# Altium schematic sheet BaseFunctions.SchDoc
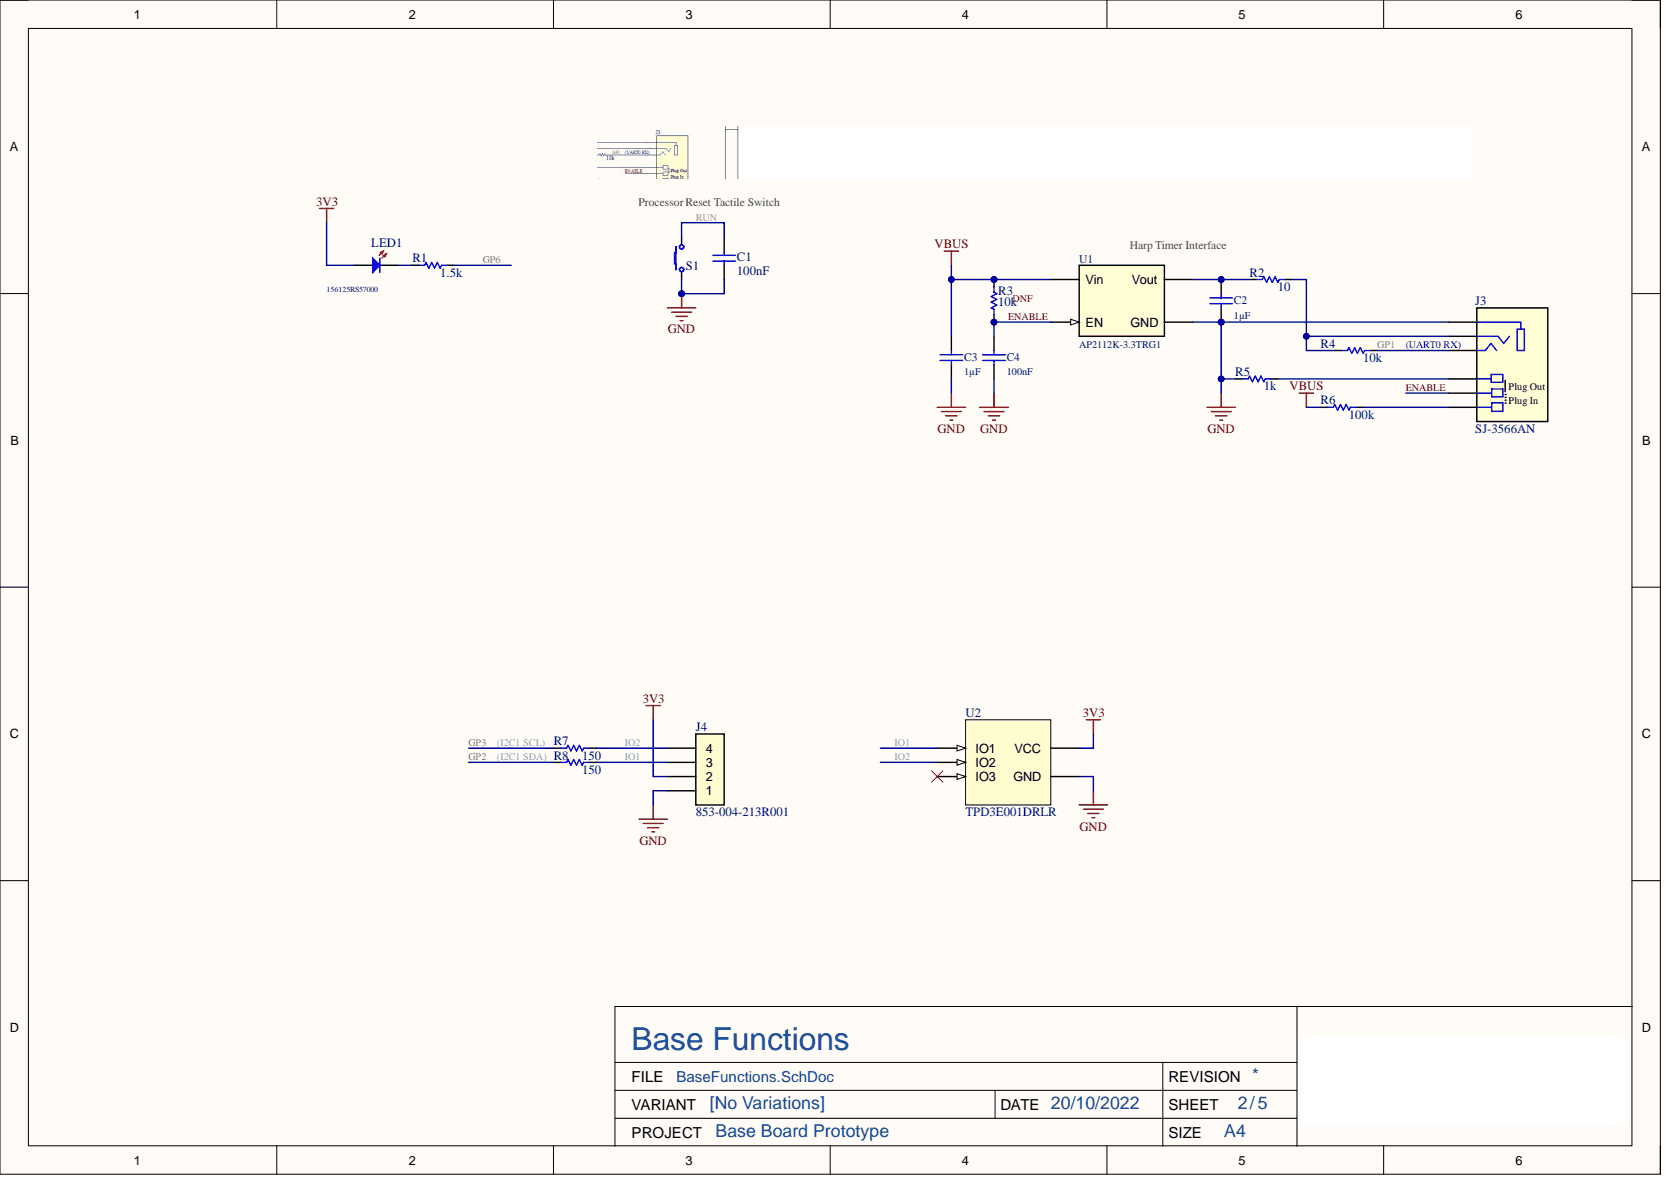
[diagram p0: BaseFunctions.SchDoc]

## Parts
C1  value 100nF  Capacitance: 100nF  [FP-GRM188-0_1-e0_2_0_5-IPC_B]  (hidden comment: C0603-100nF-100V-10%-X7R)
C2  value 1µF  [FP-GRM188-0_1-e0_2_0_5-IPC_B]  (hidden comment: C0603-1uF-25V-10%-X7R)
C3  value 1µF  [FP-GRM188-0_1-e0_2_0_5-IPC_B]  (hidden comment: C0603-1uF-25V-10%-X7R)
C4  value 100nF  Capacitance: 100nF  [FP-GRM188-0_1-e0_2_0_5-IPC_B]  (hidden comment: C0603-100nF-100V-10%-X7R)
J3  SJ-3566AN  [CUI_SJ-3566AN - RM]
J4  853-004-213R001  [853-004-213R00Y]
LED1  156125RS57000  [WL-SMRD_1205_normal use]
R1  value 1.5k  Resistance: 1.5k  [FP-CPF0603-IPC_B]  (hidden comment: R0603-1k5-1/16W-0.1%)
R2  value 10  [FP-CPF0603-IPC_B]  (hidden comment: R0603-10R-1/16W-0.1%)
R3  value 10k  Resistance: 10k  [FP-CPF0603-IPC_B]  (hidden comment: R0603-10k-1/16W-0.1%)
R4  value 10k  Resistance: 10k  [FP-CPF0603-IPC_B]  (hidden comment: R0603-10k-1/16W-0.1%)
R5  value 1k  Resistance: 1k  [FP-CPF0603-IPC_B]  (hidden comment: R0603-1k-1/16W-0.1%)
R6  value 100k  Resistance: 100k  [FP-CPF0603-IPC_B]  (hidden comment: R0603-100k-1/16W-0.1%)
R7  value 150  [FP-CPF0603-IPC_B]  (hidden comment: R0603-150R-1/16W-0.1%)
R8  value 150  [FP-CPF0603-IPC_B]  (hidden comment: R0603-150R-1/16W-0.1%)
S1  PTS645VM83-2LFS  [PTS645VM83-2LFS]  (value not drawn)
U1  AP2112K-3.3TRG1  [FP-SOT25-IPC_B]
U2  TPD3E001DRLR  [DRL5_TEX]

## Nets
3V3: J4.2 LED1.1 U2.5(VCC)
GND: C1.1 C2.1 C3.1 C4.1 J3.1 J4.1 R5.1 S1.1 U1.2(GND) U2.3(GND)
VBUS: C3.2 R3.2 R6.1 U1.1(Vin)
ENABLE: C4.2 J3.5 R3.1 U1.3(EN)
GP1: J3.2 R4.2
GP2: R8.1
GP3: R7.1
GP6: R1.2
IO1: J4.3 R8.2 U2.1(IO1)
IO2: J4.4 R7.2 U2.2(IO2)
RUN: C1.2 S1.2
NetC2_2: C2.2 R2.1 U1.5(Vout)
NetJ3_3: J3.3 R2.2 R4.1
NetJ3_4: J3.4 R5.2
NetJ3_6: J3.6 R6.2
NetLED1_2: LED1.2 R1.1

Not connected: U2 4(IO3)

## Library data of the parts
C1 C4 = CMP-611-0027-15: 0.1µF ±10% 100V Ceramic Capacitor X7R 0603 (1608 Metric); Pick&PlaceFootprint: 0603_CAP; Capacitor Type: Ceramic; Case Code (Imperial): 0603; Case Code (Metric): 1608; Case/Package: 0603; Component Kind: Standard; Construction: Flat; Depth: 0.8mm; Dielectric: X7R; Height: 0.8mm; Lead Free: Lead Free; Length: 1.6mm; Material: Ceramic; Max Operating Temperature: 125°C; Min Operating Temperature: -55°C; Mount: Surface Mount; Package Quantity: 1; Packaging: Tape and Reel; Radiation Hardening: No; REACH SVHC: No SVHC; RoHS: Yes; RoHS Compliant: Yes; Series: GRM; Size: 0.8mm; Termination: SMD/SMT; Thickness: 0.035inch; Tolerance: 10%; Voltage: 100V; Voltage Rating: 100V; Voltage Rating (DC): 100V; Weight: 0.000222oz; Width: 0.8mm; Library Name: Sainsbury Wellcome Centre
C2 C3 = CMP-611-0033-2: 1µF ±10% 25V Ceramic Capacitor X7R 0603 (1608 Metric); Capacitance: 1uF; Pick&PlaceFootprint: 0603_CAP; Capacitor Type: Ceramic; Case Code (Imperial): 0603; Case Code (Metric): 1608; Case/Package: 0603; Component Kind: Standard; Construction: Flat; Depth: 0.8mm; Dielectric: X7R; Height: 0.9mm; Lead Free: Lead Free; Length: 1.6mm; Material: Ceramic; Max Operating Temperature: 125°C; Min Operating Temperature: -55°C; Mount: Surface Mount; Package Quantity: 1; Packaging: Tape and Reel; Radiation Hardening: No; REACH SVHC: Yes; RoHS: Yes; RoHS Compliant: Yes; Series: GRM; Size: 0.8mm; Termination: Wraparound; Thickness: 0.035inch; Tolerance: 10%; Voltage: 25V; Voltage Rating: 25V; Voltage Rating (DC): 25V; Weight: 0.000222oz; Width: 0.8mm; Library Name: Sainsbury Wellcome Centre
J3 = CMP-300-0000-5: 3.5 mm, Stereo, Right Angle, Through Hole, SPDT Switch, Audio Jack Connector; Connector Type: AUDIO/RCA CONNECTOR; DIN Conformance: No; Number of Poles: 3; Number of Ports: 1; Option: General Purpose; Pick&PlaceFootprint: SJ-356xxx; Body/Shell Style: JACK; Component Kind: Standard; Contact Finish - Mating: NOT SPECIFIED; IEC Conformance: No; MIL Conformance: No; Mount: Through Hole; PartNumber: SJ-3566AN; REACH SVHC: Yes; RoHS Compliant: Yes; Termination: Solder; Library Name: Sainsbury Wellcome Centre
J4 = CMP-370-0028-2: CONN PLUG FMALE 4POS GOLD SOLDER; Connector Type: Receptacle; Current Rating: 1A; Number of Positions: 4; Ratings: IP67,Waterproof,Dust Tight; Voltage Rating: 60V; Component Kind: Standard; Contact Plating: Gold; Fastening Type: Threaded; Max Operating Temperature: 85°C; Min Operating Temperature: -40°C; Mount: Through Hole,Panel; Number of Pins: 4; Packaging: Tray; REACH SVHC: No SVHC; RoHS Compliant: Yes; Termination: Solder; Library Name: Sainsbury Wellcome Centre
LED1 = CMP-520-0011-1: Single Color LED; Component Kind: Standard; Mount: Surface Mount; Optoelectronic Device Type: SINGLE COLOR LED; PartNumber: 156125RS57000; REACH SVHC: Yes; RoHS Compliant: Yes; Library Name: Sainsbury Wellcome Centre
R1 = CMP-633-0029-14: Surface Mount Resistor 1k5 Ohm 1/16 W 0.1% 0603 (1608 Metric); Max Power Dissipation: 62.5 mW; Number of Resistors: 1; Pick&PlaceFootprint: R0603; Power Rating: 62.5mW; Temperature Coefficient: 25ppm/°C; Voltage Rating: 50V; Case Code (Imperial): 0603; Case Code (Metric): 1608; Case/Package: 0603; Component Kind: Standard; Composition: Thin Film; ELV: Compliant; Height: 0.45mm; Length: 1.6mm; Max Operating Temperature: 155°C; Min Operating Temperature: -55°C; Mount: Surface Mount; Number of Pins: 2; Number of Terminations: 2; Packaging: Tape and Reel; REACH SVHC: No SVHC; Resistance Tolerance: 0.1%; Resistor Type: Thin Film; RoHS: Yes; RoHS Compliant: Yes; Series: CPF; Size Code: 0603; Technology: Thin Film; Termination: Solder; Tolerance: 0.1%; Voltage Rating (DC): 50V; Weight: 7.1E-05oz; Width: 0.8mm; Library Name: Sainsbury Wellcome Centre
R2 = CMP-633-0069-10: Surface Mount Resistor 10 Ohm 1/16 W 0.1% 0603 (1608 Metric); Max Power Dissipation: 62.5 mW; Number of Resistors: 1; Pick&PlaceFootprint: R0603; Power Rating: 62.5mW; Temperature Coefficient: 25ppm/°C; Voltage Rating: 50V; Case Code (Imperial): 0603; Case Code (Metric): 1608; Case/Package: 0603; Component Kind: Standard; Composition: Thin Film; ELV: Compliant; Height: 0.45mm; Length: 1.6mm; Max Operating Temperature: 155°C; Min Operating Temperature: -55°C; Mount: Surface Mount; Number of Pins: 2; Number of Terminations: 2; Packaging: Tape and Reel; REACH SVHC: No SVHC; Resistance: 10; Resistance Tolerance: 0.1%; Resistor Type: Thin Film; RoHS: Yes; RoHS Compliant: Yes; Series: CPF; Size Code: 0603; Technology: Thin Film; Termination: Solder; Tolerance: 0.1%; Voltage Rating (DC): 50V; Weight: 7.1E-05oz; Width: 0.8mm; Library Name: Sainsbury Wellcome Centre
R3 R4 = CMP-633-0002-15: Surface Mount Resistor 10k Ohm 1/16 W 0.1% 0603 (1608 Metric); Max Power Dissipation: 62.5 mW; Number of Resistors: 1; Pick&PlaceFootprint: R0603; Power Rating: 62.5mW; Temperature Coefficient: 25ppm/°C; Voltage Rating: 50V; Case Code (Imperial): 0603; Case Code (Metric): 1608; Case/Package: 0603; Component Kind: Standard; Composition: Thin Film; ELV: Compliant; Height: 0.45mm; Length: 1.6mm; Max Operating Temperature: 155°C; Min Operating Temperature: -55°C; Mount: Surface Mount; Number of Pins: 2; Number of Terminations: 2; Packaging: Tape and Reel; REACH SVHC: No SVHC; Resistance Tolerance: 0.1%; Resistor Type: Thin Film; RoHS: Yes; RoHS Compliant: Yes; Series: CPF; Size Code: 0603; Technology: Thin Film; Termination: Solder; Tolerance: 0.1%; Voltage Rating (DC): 50V; Weight: 7.1E-05oz; Width: 0.8mm; Library Name: Sainsbury Wellcome Centre
R5 = CMP-633-0013-15: Surface Mount Resistor 1k Ohm 1/16 W 0.1% 0603 (1608 Metric); Max Power Dissipation: 62.5 mW; Number of Resistors: 1; Pick&PlaceFootprint: R0603; Power Rating: 62.5mW; Temperature Coefficient: 25ppm/°C; Voltage Rating: 50V; Case Code (Imperial): 0603; Case Code (Metric): 1608; Case/Package: 0603; Component Kind: Standard; Composition: Thin Film; ELV: Compliant; Height: 0.45mm; Length: 1.6mm; Max Operating Temperature: 155°C; Min Operating Temperature: -55°C; Mount: Surface Mount; Number of Pins: 2; Number of Terminations: 2; Packaging: Tape and Reel; REACH SVHC: No SVHC; Resistance Tolerance: 0.1%; Resistor Type: Thin Film; RoHS: Yes; RoHS Compliant: Yes; Series: CPF; Size Code: 0603; Technology: Thin Film; Termination: Solder; Tolerance: 0.1%; Voltage Rating (DC): 50V; Weight: 7.1E-05oz; Width: 0.8mm; Library Name: Sainsbury Wellcome Centre
R6 = CMP-633-0000-17: Surface Mount Resistor 100k Ohm 1/16 W 0.1% 0603 (1608 Metric); Max Power Dissipation: 62.5 mW; Number of Resistors: 1; Pick&PlaceFootprint: R0603; Power Rating: 62.5mW; Temperature Coefficient: 25ppm/°C; Voltage Rating: 50V; Case Code (Imperial): 0603; Case Code (Metric): 1608; Case/Package: 0603; Component Kind: Standard; Composition: Thin Film; ELV: Compliant; Height: 0.45mm; Length: 1.6mm; Max Operating Temperature: 155°C; Min Operating Temperature: -55°C; Mount: Surface Mount; Number of Pins: 2; Number of Terminations: 2; Packaging: Tape and Reel; REACH SVHC: No SVHC; Resistance Tolerance: 0.1%; Resistor Type: Thin Film; RoHS: Yes; RoHS Compliant: Yes; Series: CPF; Size Code: 0603; Technology: Thin Film; Termination: Solder; Tolerance: 0.1%; Voltage Rating (DC): 50V; Weight: 7.1E-05oz; Width: 0.8mm; Library Name: Sainsbury Wellcome Centre
R7 R8 = CMP-633-0078-2: Surface Mount Resistor 150 Ohm 1/16 W 0.1% 0603 (1608 Metric); Max Power Dissipation: 62.5 mW; Number of Resistors: 1; Pick&PlaceFootprint: R0603; Power Rating: 62.5mW; Temperature Coefficient: 25ppm/°C; Voltage Rating: 50V; Case Code (Imperial): 0603; Case Code (Metric): 1608; Case/Package: 0603; Component Kind: Standard; Composition: Thin Film; Construction: Chip; ELV: Compliant; Height: 0.45mm; Length: 1.6mm; Max Operating Temperature: 155°C; Min Operating Temperature: -55°C; Mount: Surface Mount; Number of Pins: 2; Number of Terminations: 2; Packaging: Tape and Reel; REACH SVHC: Yes; Resistance: 150; Resistance Tolerance: 0.1%; Resistor Type: FIXED RESISTOR; RoHS: Yes; RoHS Compliant: Yes; Series: CPF; Size Code: 0603; Technology: THIN FILM; Termination: Wraparound; Tolerance: 0.1%; Voltage Rating (DC): 50V; Weight: 7.1E-05oz; Width: 0.8mm; Library Name: Sainsbury Wellcome Centre
S1 = CMP-B00-0002-2: Switch Tactile N.O. SPST Round Button PC Pins 0.05A 12VDC 100000Cycles 1.57N Bracket Mount/Through Hole Bulk; Actuator Material: Nylon,Polyamide,PA66; Actuator Type: Standard; Current Rating: 50mA; Illumination Type: Non-Illuminated; Switch Function: Off-Mom; Voltage Rating (DC): 12V; Color: Black; Component Kind: Standard; Contact Plating: Silver; Depth: 12.3mm; Length: 7.5mm; Max Current Rating: 50mA; Max Operating Temperature: 60°C; Mechanical Life: 100000Cycles; Min Operating Temperature: -20°C; Mount: Through Hole; Operating Force: 1.57N; Orientation: Right Angle; Package Quantity: 1000; Packaging: Bulk; RoHS Compliant: Yes; Series: PTS645; Termination: PC Pins; Throw Configuration: SPST; Library Name: Sainsbury Wellcome Centre
U1 = CMP-970-0025-2: Fixed Positive LDO Regulator, 3.3V, 0.4V Dropout, CMOS, PDSO5; Dropout Voltage1-Max: 400mV; Dropout Voltage1-Nom: 250mV; Input Voltage Absolute-Max: 6.5V; Line Regulation-Max: 0.00561%; Load Regulation-Max: 0.0198%; Max Input Voltage: 6V; Min Input Voltage: 2.5V; Number of Functions: 1; Output Current1-Max: 600mA; Output Voltage1-Max: 3.3495V; Output Voltage1-Min: 3.2505V; Package Body Material: Plastic; Package Equivalence Code: TSOP5/6,.11,37; Pick&PlaceFootprint: SOT25; Qualification Status: Not Qualified; Regulator Type: FIXED POSITIVE SINGLE OUTPUT LDO REGULATOR; Voltage Tolerance-Max: 1.5%; Adjustability: FIXED; Component Kind: Standard; Height - Seated (Max): 1.45mm; JESD-30 Code: R-PDSO-G5; JESD-609 Code: e3; Length: 2.92mm; Max Operating Temperature: 85°C; Min Operating Temperature: -40°C; Moisture Sensitivity Level: 3; Number of Outputs: 1; Number of Pins: 5; Operating Temperature, TJ-Max: 85°C; Operating Temperature, TJ-Min: -40°C; Output Voltage1-Nom: 3.3V; Package Code: LSSOP; Package Shape: Rectangular; Package Style: SMALL OUTLINE, LOW PROFILE, SHRINK PITCHMeter; Packaging: Tape and Reel; PartNumber: AP2112K-3.3TRG1; REACH SVHC: Yes; RoHS Compliant: Yes; Surface Mount: Yes; Technology: CMOS; Terminal Finish: Tin; Terminal Form: Gull Wing; Terminal Pitch: 0.95mm; Terminal Position: DUAL; Width: 1.6mm; Library Name: Sainsbury Wellcome Centre
U2 = CMP-820-0000-4: Low-Capacitance 3-Channel +/-15KV ESD-Protection Array for High-Speed Data Interfaces 5-SOT-5X3; Pick&PlaceFootprint: DRL-5; Component Kind: Standard; Mount: Surface Mount; PartNumber: TPD3E001DRLR; Library Name: Sainsbury Wellcome Centre
